# Altium schematic sheet GasCap.CAMM8Q.SchDoc
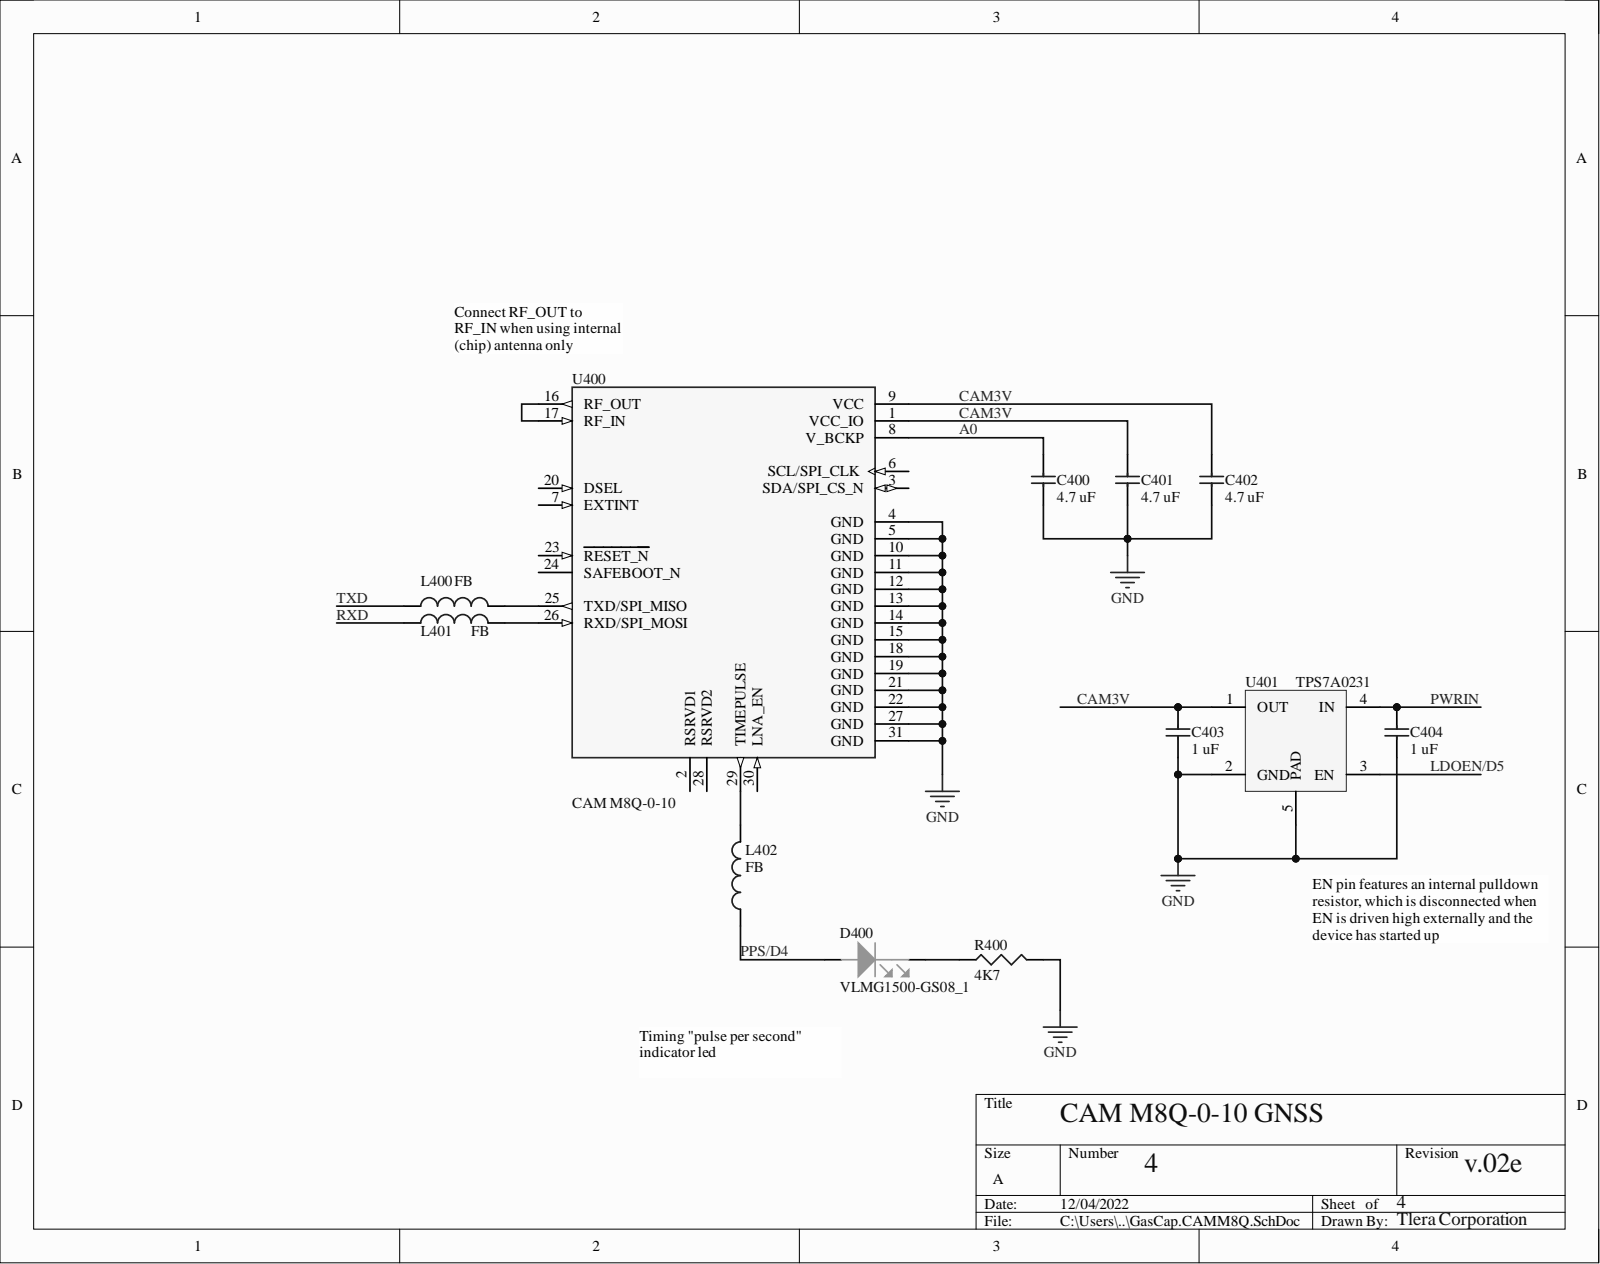
[diagram p0: GasCap.CAMM8Q.SchDoc]

## Parts
C400  4.7 uF  [402Cap]
C401  4.7 uF  [402Cap]
C402  4.7 uF  [402Cap]
C403  1 uF  [402Cap]
C404  1 uF  [402Cap]
D400  VLMG1500-GS08_1  [0402LED]
L400  FB  [402IND]
L401  FB  [402IND]
L402  FB  [402IND]
R400  4K7  [402RES]
U400  CAM M8Q-0-10  [LCC100P960X1400X210-31N]
U401  TPS7A0231  [TPS7A02]

## Nets
GND: C400.1 C401.1 C402.1 C403.1 C404.1 R400.2 U400.4(GND) U400.5(GND) U400.10(GND) U400.11(GND) U400.12(GND) U400.13(GND) U400.14(GND) U400.15(GND) U400.18(GND) U400.19(GND) U400.21(GND) U400.22(GND) U400.27(GND) U400.31(GND) U401.2(GND) U401.5(PAD)
A0: C400.2 U400.8(V_BCKP)
CAM3V: C401.2 C402.2 C403.2 U400.1(VCC_IO) U400.9(VCC) U401.1(OUT)
LDOEN/D5: U401.3(EN)
PPS/D4: D400.2 L402.1
PWRIN: C404.2 U401.4(IN)
RXD: L401.1
TXD: L400.1
NetD400_1: D400.1 R400.1
NetL400_2: L400.2 U400.25(TXD/SPI_MISO)
NetL401_2: L401.2 U400.26(RXD/SPI_MOSI)
NetL402_2: L402.2 U400.29(TIMEPULSE)
NetU400_16: U400.16(RF_OUT) U400.17(RF_IN)

Not connected: U400 2(RSRVD1) 3(SDA/SPI_CS_N) 6(SCL/SPI_CLK) 7(EXTINT) 20(DSEL) 23(RESET_N) 24(SAFEBOOT_N) 28(RSRVD2) 30(LNA_EN)

## Library data of the parts
C400-C402 = 0402YD475MAT2A: Ceramic Capacitor, Multilayer, Ceramic, 16V, 20% +Tol, 20% -Tol, X5R, 15% TC, 4.7uF, Surface Mount, 0402; Manufacturer: Kyocera AVX; Multilayer: Yes; Capacitance: 4.7uF; Capacitor Type: Ceramic; Category: Ceramic Capacitors; Component Kind: Standard; ComponentLink1Description: https://datasheet.ciiva.com:443/pdfs/VipMasterIC/IC/AVXC/AVXC-S-A0009640604/AVXC-S-A0009640604-1.pdf?src-supplier=IHS+Markit; ComponentLink1URL: https://datasheet.ciiva.com:443/pdfs/VipMasterIC/IC/AVXC/AVXC-S-A0009640604/AVXC-S-A0009640604-1.pdf?src-supplier=IHS+Markit; ComponentLink2Description: http://catalogs.avx.com/SurfaceMount.pdf?src-supplier=Digi-Key; ComponentLink2URL: http://catalogs.avx.com/SurfaceMount.pdf?src-supplier=Digi-Key; ComponentLink3Description: http://datasheets.avx.com/cx5r.pdf?src-supplier=Digi-Key; ComponentLink3URL: http://datasheets.avx.com/cx5r.pdf?src-supplier=Digi-Key; Dielectric Material: Ceramic; JESD-609 Code: e3; Manufacturer Part Number: 0402YD475MAT2A; Max Operating Temperature: 85°C; Min Operating Temperature: -55°C; Mount: Surface Mount; Negative Tolerance: 20%; Number of Pins: 2; Package Shape: Rectangular; Packaging: Tape and Reel; Positive Tolerance: 20%; Pricing 1: 1=0.26000, 10=0.18000, 50=0.12020, 100=0.10210, 500=0.07208, 1000=0.06306, 2500=0.06006, 5000=0.05556 (USD); Rated DC Voltage (URdc): 16V; REACH SVHC: Yes; RoHS: Yes; RoHS Compliant: Yes; Size Code: 0402; Stock 1: 792844; Supplier 1: Digi-Key; Supplier Part Number 1: 478-10788-1-ND; Temperature Characteristics Code: X5R; Terminal Finish: Nickel; Termination: Wraparound; Library Name: GasCap.SchLib
C403 C404 = GRT155R61C105KE01D: 0402 1 uF Ceramic Capacitor, Multilayer, Ceramic, 16V, 10% +Tol, 10% -Tol, X5R, 15% TC, 1uF, Surface Mount, 0402; Manufacturer: Murata; Multilayer: Yes; Reference Standard: AEC-Q200; Capacitance: 1uF; Capacitor Type: Ceramic; Category: Ceramic Capacitors; Component Kind: Standard; ComponentLink1Description: https://datasheet.ciiva.com:443/pdfs/VipMasterIC/IC/MURE/MURE-S-A0004410517/MURE-S-A0004410643-1.pdf?src-supplier=IHS+Markit; ComponentLink1URL: https://datasheet.ciiva.com:443/pdfs/VipMasterIC/IC/MURE/MURE-S-A0004410517/MURE-S-A0004410643-1.pdf?src-supplier=IHS+Markit; ComponentLink2Description: http://search.murata.co.jp/Ceramy/image/img/A01X/G101/ENG/GRT155R61C105KE01-01.pdf?src-supplier=Digi-Key; ComponentLink2URL: http://search.murata.co.jp/Ceramy/image/img/A01X/G101/ENG/GRT155R61C105KE01-01.pdf?src-supplier=Digi-Key; Dielectric Material: Ceramic; JESD-609 Code: e3; Manufacturer Part Number: GRT155R61C105KE01D; Max Operating Temperature: 85°C; Min Operating Temperature: -55°C; Mount: Surface Mount; Negative Tolerance: 10%; Number of Pins: 2; Package Shape: Rectangular; Packaging: Tape and Reel; Positive Tolerance: 10%; Pricing 1: 1=0.12000, 10=0.08300, 50=0.04740, 100=0.03920, 500=0.02752, 1000=0.02278, 2500=0.02120, 5000=0.01939 (USD); Rated DC Voltage (URdc): 16V; REACH SVHC: Yes; RoHS: Yes; RoHS Compliant: Yes; Size Code: 0402; Stock 1: 691618; Supplier 1: Digi-Key; Supplier Part Number 1: 490-12255-1-ND; Temperature Characteristics Code: X5R; Terminal Finish: Nickel; Termination: Wraparound; Library Name: Gnat.SchLib
D400 = VLMG1500-GS08_1: Single Color LED, Yellow Green, 0.5mm; Manufacturer: Vishay; Color: Green,Yellow; Forward Current-Max: 30mA; Forward Voltage-Max: 2.4V; Luminous Intensity-Nom: 90mcd; Number of Functions: 1; Overall Height: 0.35mm; Reverse Voltage-Max: 5V; Shape: Rectangular; Viewing Angle: 130°; Category: LEDs; Color@wavelength: Green; Component Kind: Standard; ComponentLink1Description: https://datasheet.ciiva.com:443/pdfs/VipMasterIC/IC/VISH/VISH-S-A0003457490/VISH-S-A0003457490-1.pdf?src-supplier=IHS+Markit; ComponentLink1URL: https://datasheet.ciiva.com:443/pdfs/VipMasterIC/IC/VISH/VISH-S-A0003457490/VISH-S-A0003457490-1.pdf?src-supplier=IHS+Markit; ComponentLink2Description: https://datasheet.ciiva.com/13945/vlmo1500-13945360.pdf?src-supplier=Digi-Key; ComponentLink2URL: https://datasheet.ciiva.com/13945/vlmo1500-13945360.pdf?src-supplier=Digi-Key; ComponentLink3Description: https://datasheet.ciiva.com/12071/vlmo1500-244705-12071911.pdf?src-supplier=Mouser; ComponentLink3URL: https://datasheet.ciiva.com/12071/vlmo1500-244705-12071911.pdf?src-supplier=Mouser; ComponentLink4Description: https://datasheet.ciiva.com/13945/vlmo1500-13945358.pdf?src-supplier=Digi-Key; ComponentLink4URL: https://datasheet.ciiva.com/13945/vlmo1500-13945358.pdf?src-supplier=Digi-Key; ComponentLink5Description: https://datasheet.ciiva.com/13945/vlmo1500-13945359.pdf?src-supplier=Digi-Key; ComponentLink5URL: https://datasheet.ciiva.com/13945/vlmo1500-13945359.pdf?src-supplier=Digi-Key; ComponentLink6Description: https://datasheet.ciiva.com/30005/vlmo1500-30005134.pdf?src-supplier=Avnet; ComponentLink6URL: https://datasheet.ciiva.com/30005/vlmo1500-30005134.pdf?src-supplier=Avnet; Manufacturer Part Number: VLMG1500-GS08; Max Operating Temperature: 85°C; Min Operating Temperature: -30°C; Mount: Surface Mount; Number of Pins: 2; Optoelectronic Device Type: SINGLE COLOR LED; Packaging: Tape and Reel; Pricing 1: 1=0.43000, 10=0.32200, 100=0.18250, 500=0.12084, 1000=0.09264 (USD); REACH SVHC: Yes; RoHS: Yes; RoHS Compliant: Yes; Size: 0.5mm; Stock 1: 6075; Supplier 1: Digi-Key; Supplier Part Number 1: VLMG1500-GS08CT-ND; Terminal Pitch: 0.95mm; Library Name: GasCap.SchLib
L400-L402 = BLM15HD102SN1D: 0402 Ferrite Chip, 1 Function(s), 0.25A, 2 Pin(s); Manufacturer: Murata; Manufacturer Part Number: BLM15AX121SN1D; Current Rating: 700mA; DC Resistance-Max: 130mR; Max Frequency: 100MHz; Number of Functions: 1; Output Impedance: 120R; Case Code: 0402; Category: Inductors; Component Kind: Standard; ComponentLink1Description: https://datasheet.ciiva.com:443/pdfs/VipMasterIC/IC/MURE/MURE-S-A0010009827/MURE-S-A0010009827-1.pdf?src-supplier=IHS+Markit; ComponentLink1URL: https://datasheet.ciiva.com:443/pdfs/VipMasterIC/IC/MURE/MURE-S-A0010009827/MURE-S-A0010009827-1.pdf?src-supplier=IHS+Markit; ComponentLink2Description: https://datasheet.ciiva.com/41449/001172167-da-01-en-drossel-0402-120r-1-0x0-5mm-10000st-41449456.pdf?src-supplier=Conrad; ComponentLink2URL: https://datasheet.ciiva.com/41449/001172167-da-01-en-drossel-0402-120r-1-0x0-5mm-10000st-41449456.pdf?src-supplier=Conrad; ComponentLink3Description: https://datasheet.ciiva.com/1012/l0110e-1012387.pdf?src-supplier=Digi-Key; ComponentLink3URL: https://datasheet.ciiva.com/1012/l0110e-1012387.pdf?src-supplier=Digi-Key; ComponentLink4Description: https://datasheet.ciiva.com/1012/l0110e-1012386.pdf?src-supplier=Digi-Key; ComponentLink4URL: https://datasheet.ciiva.com/1012/l0110e-1012386.pdf?src-supplier=Digi-Key; ComponentLink5Description: https://datasheet.ciiva.com/1012/l0110e-1012388.pdf?src-supplier=Digi-Key; ComponentLink5URL: https://datasheet.ciiva.com/1012/l0110e-1012388.pdf?src-supplier=Digi-Key; ComponentLink6Description: https://datasheet.ciiva.com:443/pdfs/VipMasterIC/IC/MURE/MURE-S-A0008871331/MURE-S-A0008871390-1.pdf?src-supplier=IHS+Markit; ComponentLink6URL: https://datasheet.ciiva.com:443/pdfs/VipMasterIC/IC/MURE/MURE-S-A0008871331/MURE-S-A0008871390-1.pdf?src-supplier=IHS+Markit; ComponentLink7Description: https://datasheet.ciiva.com/27054/1844707-27054220.pdf?src-supplier=Jameco; ComponentLink7URL: https://datasheet.ciiva.com/27054/1844707-27054220.pdf?src-supplier=Jameco; ComponentLink8Description: https://datasheet.ciiva.com/11799/0900766b811b5866-11799124.pdf?src-supplier=RSComponents; ComponentLink8URL: https://datasheet.ciiva.com/11799/0900766b811b5866-11799124.pdf?src-supplier=RSComponents; ComponentLink9Description: https://datasheet.ciiva.com/18089/getdatasheetpartid-153027-18089625.pdf?src-supplier=Verical; ComponentLink9URL: https://datasheet.ciiva.com/18089/getdatasheetpartid-153027-18089625.pdf?src-supplier=Verical; ComponentLink10Description: https://datasheet.ciiva.com/41425/001171683-da-01-en-chip-drossel0402-1000r-250ma-1-0x0-5mm-41425767.pdf?src-supplier=Conrad; ComponentLink10URL: https://datasheet.ciiva.com/41425/001171683-da-01-en-chip-drossel0402-1000r-250ma-1-0x0-5mm-41425767.pdf?src-supplier=Conrad; ComponentLink11Description: https://datasheet.ciiva.com/41449/001172200-da-01-en-drossel-0402-1000r-21-0x0-5mm-10000st-41449488.pdf?src-supplier=Conrad; ComponentLink11URL: https://datasheet.ciiva.com/41449/001172200-da-01-en-drossel-0402-1000r-21-0x0-5mm-10000st-41449488.pdf?src-supplier=Conrad; ComponentLink12Description: https://datasheet.ciiva.com/1466/5168-1466860.pdf?src-supplier=Element14; ComponentLink12URL: https://datasheet.ciiva.com/1466/5168-1466860.pdf?src-supplier=Element14; ComponentLink13Description: https://datasheet.ciiva.com/1466/5168-1466859.pdf?src-supplier=Element14; ComponentLink13URL: https://datasheet.ciiva.com/1466/5168-1466859.pdf?src-supplier=Element14; ComponentLink14Description: https://datasheet.ciiva.com/1012/l0110s0101blm15h-1012795.pdf?src-supplier=Digi-Key; ComponentLink14URL: https://datasheet.ciiva.com/1012/l0110s0101blm15h-1012795.pdf?src-supplier=Digi-Key; ComponentLink15Description: https://datasheet.ciiva.com/1012/l0110s0101blm15h-1012794.pdf?src-supplier=Digi-Key; ComponentLink15URL: https://datasheet.ciiva.com/1012/l0110s0101blm15h-1012794.pdf?src-supplier=Digi-Key; ComponentLink16Description: https://datasheet.ciiva.com/1012/l0110s0101blm15h-1012796.pdf?src-supplier=Digi-Key; ComponentLink16URL: https://datasheet.ciiva.com/1012/l0110s0101blm15h-1012796.pdf?src-supplier=Digi-Key; ComponentLink17Description: https://datasheet.ciiva.com/3081/l0110s0101blm15h-3081604.pdf?src-supplier=Avnet; ComponentLink17URL: https://datasheet.ciiva.com/3081/l0110s0101blm15h-3081604.pdf?src-supplier=Avnet; ComponentLink18Description: https://datasheet.ciiva.com/16373/l0110s0101blm15h-16373866.pdf?src-supplier=Future+Electronics; ComponentLink18URL: https://datasheet.ciiva.com/16373/l0110s0101blm15h-16373866.pdf?src-supplier=Future+Electronics; ComponentLink19Description: https://datasheet.ciiva.com/3081/l0110s0101blm15h-3081605.pdf?src-supplier=Avnet; ComponentLink19URL: https://datasheet.ciiva.com/3081/l0110s0101blm15h-3081605.pdf?src-supplier=Avnet; Construction: Chip Bead; Filter Type: FERRITE CHIP; Height: 0.5mm; JESD-609 Code: e3; Length: 1mm; Material: Ferrite; Max Operating Temperature: 125°C; Min Frequency: 100MHz; Min Operating Temperature: -55°C; Mount: Surface Mount; Packaging: Tape and Reel; Pricing 1: 1=0.10000, 10=0.06700, 25=0.06040, 50=0.05440, 100=0.03990, 250=0.02904, 500=0.02662, 1000=0.02299, 5000=0.01815 (USD); Pricing 2: 1=0.14000, 10=0.11200, 25=0.08680, 50=0.07180, 100=0.06200, 250=0.04956, 500=0.04460, 1000=0.03717, 5000=0.03469 (USD); REACH SVHC: Yes; RoHS: Yes; RoHS Compliant: Yes; Stock 1: 46609; Stock 2: 408631; Supplier 1: Digi-Key; Supplier 2: Digi-Key; Supplier Part Number 1: 490-5439-1-ND; Supplier Part Number 2: 490-4003-1-ND; Terminal Finish: Tin; Width: 0.5mm; Library Name: GasCap.SchLib
R400 = RC0402FR-074K7P: 0402 4K7 Fixed Resistor, Metal Glaze/thick Film, 0.0625W, 4700ohm, 50V, 1% +/-Tol, 150ppm/Cel, Surface Mount, 0402; Manufacturer: Yageo; Additional Feature: LASER TRIMMABLE; Rated Power Dissipation (P): 62.5mW; Rated Temperature: 70°C; Resistance: 4.7kR; Temperature Coefficient: 150ppm/°C; Category: Chip SMD Resistors; Component Kind: Standard; ComponentLink1Description: https://datasheet.ciiva.com:443/pdfs/VipMasterIC/IC/YAGO/YAGO-S-A0006248068/YAGO-S-A0006248068-1.pdf?src-supplier=IHS+Markit; ComponentLink1URL: https://datasheet.ciiva.com:443/pdfs/VipMasterIC/IC/YAGO/YAGO-S-A0006248068/YAGO-S-A0006248068-1.pdf?src-supplier=IHS+Markit; ComponentLink2Description: http://www.yageo.com.tw/exep/pages/download/literatures/PYu-R_INT-thick_7.pdf?src-supplier=Digi-Key; ComponentLink2URL: http://www.yageo.com.tw/exep/pages/download/literatures/PYu-R_INT-thick_7.pdf?src-supplier=Digi-Key; ComponentLink3Description: http://www.yageo.com/NewPortal/yageodocoutput?fileName=/pdf/R-Chip/PYu-RC_51_RoHS_P_0.pdf&src-supplier=Digi-Key; ComponentLink3URL: http://www.yageo.com/NewPortal/yageodocoutput?fileName=/pdf/R-Chip/PYu-RC_51_RoHS_P_0.pdf&src-supplier=Digi-Key; JESD-609 Code: e3; Manufacturer Part Number: RC0402FR-074K7P; Max Operating Temperature: 155°C; Min Operating Temperature: -55°C; Mount: Surface Mount; Number of Pins: 2; Package Shape: Rectangular; Packaging: Tape and Reel; Pricing 1: 1=0.10000, 10=0.03400, 100=0.01390, 1000=0.00624, 2500=0.00542, 5000=0.00447 (USD); REACH SVHC: Yes; Resistor Type: FIXED RESISTOR; RoHS: Yes; RoHS Compliant: Yes; Size Code: 0402; Stock 1: 294510; Supplier 1: Digi-Key; Supplier Part Number 1: YAG1272CT-ND; Technology: METAL GLAZE/THICK FILM; Terminal Finish: Nickel; Termination: Wraparound; Tolerance: 1%; Working Voltage: 50V; Library Name: GasCap.SchLib
U400 = CAM M8Q-0-10: CAM-M8 RF Receiver BeiDou, GLONASS, GNSS, GPS, QZSS 1.575GHz -167dBm On-Board, Chip Module; Manufacturer: u-blox; Manufacturer Part Number: CAM-M8Q-0; MF: U-Blox America; Telecom IC Type: TELECOM CIRCUIT; Availability: Unavailable; Category: RF Receivers, Transceivers; Component Kind: Standard; ComponentLink1Description: https://datasheet.ciiva.com:443/pdfs/VipMasterIC/IC/UBLO/UBLO-S-A0008266178/UBLO-S-A0008266178-1.pdf?src-supplier=IHS+Markit; ComponentLink1URL: https://datasheet.ciiva.com:443/pdfs/VipMasterIC/IC/UBLO/UBLO-S-A0008266178/UBLO-S-A0008266178-1.pdf?src-supplier=IHS+Markit; ComponentLink2Description: https://www.u-blox.com/sites/default/files/CAM-M8-FW3_DataSheet_%28UBX-15031574%29.pdf?src-supplier=Digi-Key; ComponentLink2URL: https://www.u-blox.com/sites/default/files/CAM-M8-FW3_DataSheet_%28UBX-15031574%29.pdf?src-supplier=Digi-Key; MP: CAM-M8Q-0; Package: SMD-31 u-blox; Price: None; Pricing 1: 1=25.00000 (USD); RoHS: Yes; RoHS Compliant: Yes; SnapEDA_Link: https://www.snapeda.com/parts/CAM-M8Q-0/U-Blox%20America%20Inc./view-part/51184/?ref=snap; Stock 1: 368; Supplier 1: Digi-Key; Supplier Part Number 1: 672-CAM-M8Q-0CT-ND; Library Name: GasCap.SchLib
U401 = TPS7A0231: Fixed Positive LDO Regulator, 3.1V, 0.36V Dropout, PDSO4; Manufacturer: Texas Instruments; Manufacturer Part Number: TPS7A0230PDQNR; Category: Voltage Regulators - Linear; Component Kind: Standard; ComponentLink1Description: https://www.ti.com:443/lit/ds/symlink/tps7a02.pdf?src-supplier=IHS+Markit; ComponentLink1URL: https://www.ti.com:443/lit/ds/symlink/tps7a02.pdf?src-supplier=IHS+Markit; Pricing 1: 1=1.58000, 10=1.41700, 25=1.34440, 100=1.10440, 250=1.03240, 500=0.91234, 1000=0.79275 (USD); Pricing 2: 3000=0.79275 (USD); Pricing 3: 1=1.58000, 10=1.42000, 25=1.35000, 50=1.35000, 100=1.11000, 250=1.04000, 500=0.91300, 1000=0.72100, 3000=0.69600, 10000=0.69600 (USD); Pricing 4: 1=1.58000, 10=1.41700, 25=1.34440, 100=1.10440, 250=1.03240, 500=0.91234, 1000=0.72480 (USD); RoHS: Yes; Stock 2: 3000; Stock 3: 1895; Stock 4: 1297; Supplier 1: Digi-Key; Supplier 2: Digi-Key; Supplier 3: Mouser; Supplier 4: Digi-Key; Supplier Part Number 1: 296-TPS7A0230PDQNRCT-ND; Supplier Part Number 2: 296-TPS7A0233DQNRTR-ND; Supplier Part Number 3: 595-TPS7A0233DQNR; Supplier Part Number 4: 296-TPS7A0231PDQNRCT-ND; Library Name: Gnat.SchLib
